# Altium schematic sheet LCD.SchDoc(LCD)
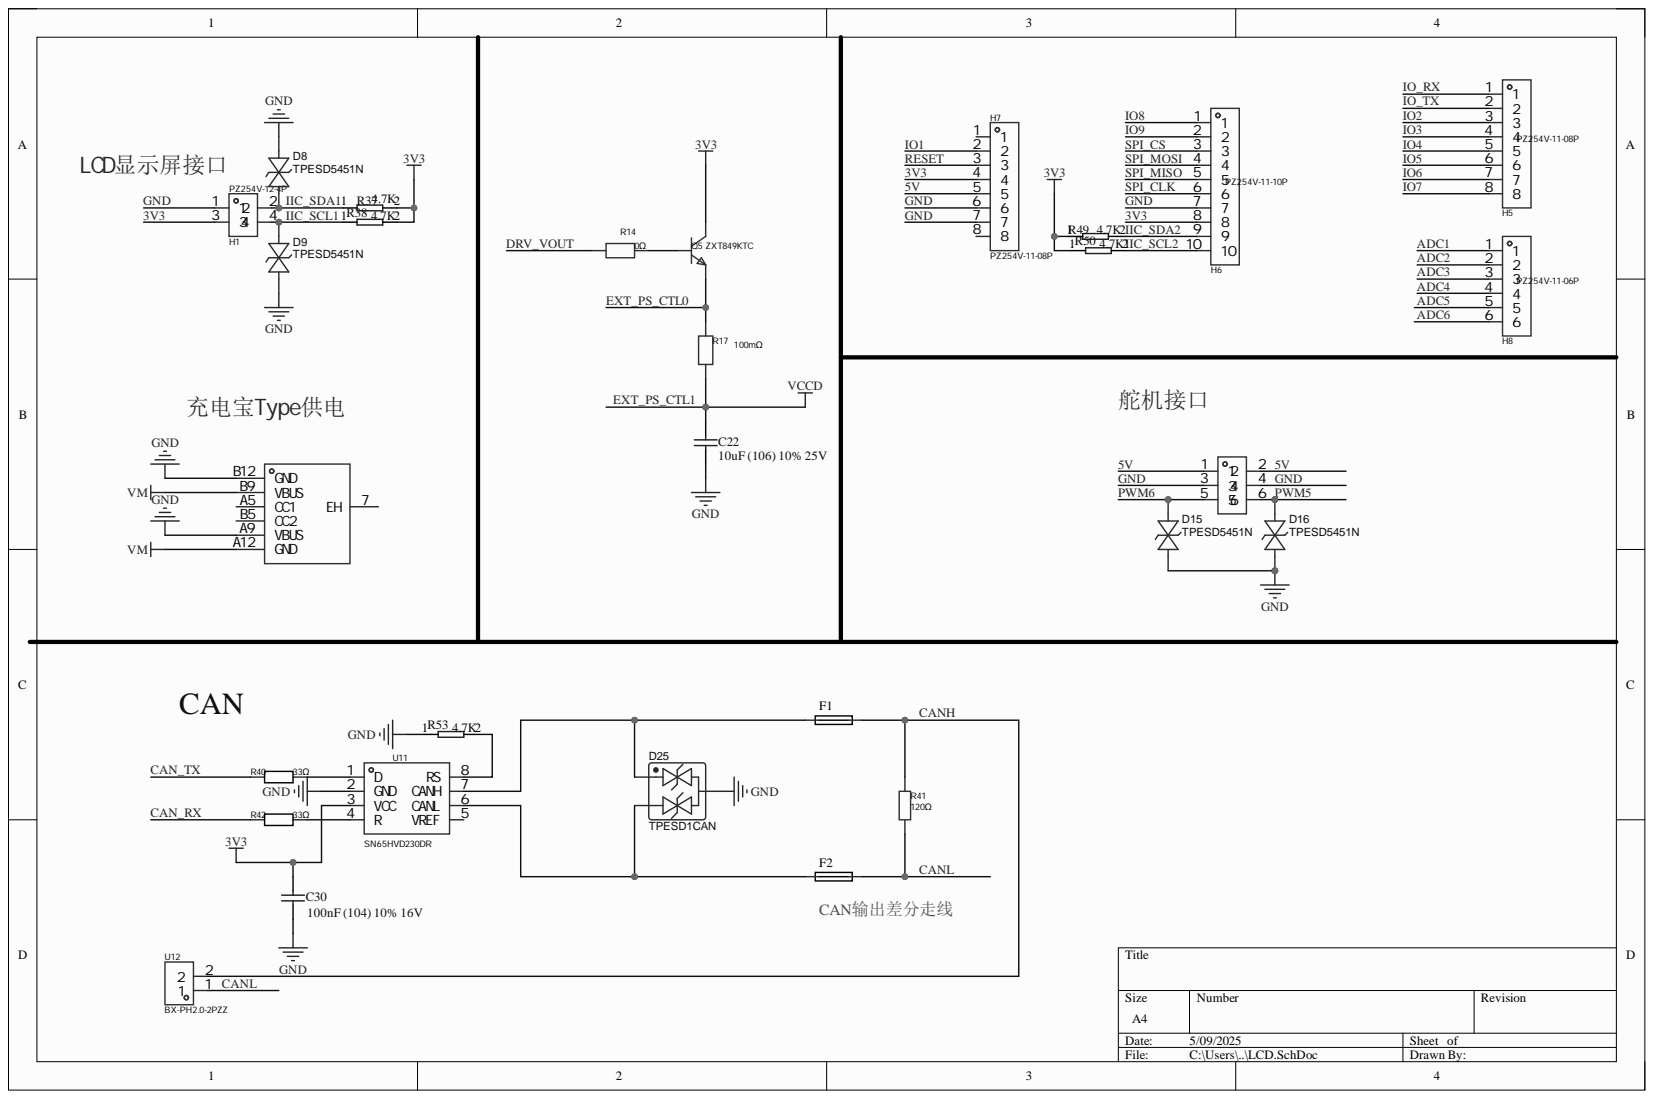
[diagram p0: LCD.SchDoc]

## Parts
C22  10uF (106) 10% 25V  [0805_C]
C30  100nF (104) 10% 16V  [0402_C]
D8  TPESD5451N  [DFN1006-2L-BI]
D9  TPESD5451N  [DFN1006-2L-BI]
D15  TPESD5451N  [DFN1006-2L-BI]
D16  TPESD5451N  [DFN1006-2L-BI]
D25  TPESD1CAN  [SOT-23-3_L2.9-W1.3-P1.90-LS2.4-BR]
F1  JK-SMD0805-005-15  [F0805]  (value not drawn)
F2  JK-SMD0805-005-15  [F0805]  (value not drawn)
H1  PZ254V-12-4P  [HDR-TH_4P-P2.54-V-M-R2-C2-S2.54]
H4  PZ254V-12-6P  [HDR-TH_6P-P2.54-V-M-R2-C3-S2.54]  (value not drawn)
H5  PZ254V-11-08P  [HDR-TH_8P-P2.54-V-M]
H6  PZ254V-11-10P  [HDR-TH_10P-P2.54-V-M]
H7  PZ254V-11-08P  [HDR-TH_8P-P2.54-V-M]
H8  PZ254V-11-06P  [HDR-TH_6P-P2.54-V-M]
Q5  ZXT849KTC  [TO-252-2_L6.6-W6.1-P4.57-LS9.9-BR-CW]
R14  0Ω  [0805_R]
R17  100mΩ  [R1206]
R37  4.7K  [R0603]
R38  4.7K  [R0603]
R40  33Ω  [0402_R]
R41  120Ω  [R0603]
R42  33Ω  [0402_R]
R49  4.7K  [R0603]
R50  4.7K  [R0603]
R53  4.7K  [R0603]
U11  SN65HVD230DR  [SOIC-8_L4.9-W3.9-P1.27-LS6.0-BL]
U12  BX-PH2.0-2PZZ  [CONN-TH_2P-P2.00_BOSSIE_BX-PH2.0-2PZZ]
USB2  TYPE-C 6P  [TYPE-C-SMD_TYPE-C-6P]  (value not drawn)

## Nets
VM: USB2.A12(GND) USB2.B9(VBUS)
3V3: C30.2 H1.3 H6.8 H7.4 Q5.2 R37.2 R38.2 R49.1 R50.1 U11.3(VCC)
5V: H4.1 H4.2 H7.5
ADC1: H8.1
ADC2: H8.2
ADC3: H8.3
ADC4: H8.4
ADC5: H8.5
ADC6: H8.6
CAN_RX: R42.1
CAN_TX: R40.1
CANH: F1.2 R41.2 U12.2
CANL: F2.2 R41.1 U12.1
DRV_VOUT: R14.1
EXT_PS_CTL0: Q5.3 R17.2
EXT_PS_CTL1: C22.2 R17.1
GND: C22.1 C30.1 D8.2 D9.1 D15.1 D16.1 D25.3 H1.1 H4.3 H4.4 H6.7 H7.6 H7.7 R53.1 U11.2(GND) USB2.A9(VBUS) USB2.B12(GND)
IIC_SCL1: D9.2 H1.4 R38.1
IIC_SCL2: H6.10 R50.2
IIC_SDA1: D8.1 H1.2 R37.1
IIC_SDA2: H6.9 R49.2
IO1: H7.2
IO2: H5.3
IO3: H5.4
IO4: H5.5
IO5: H5.6
IO6: H5.7
IO7: H5.8
IO8: H6.1
IO9: H6.2
IO_RX: H5.1
IO_TX: H5.2
PWM5: D16.2 H4.6
PWM6: D15.2 H4.5
RESET: H7.3
SPI_CLK: H6.6
SPI_CS: H6.3
SPI_MISO: H6.5
SPI_MOSI: H6.4
NetD25_1: D25.1 F1.1 U11.7(CANH)
NetD25_2: D25.2 F2.1 U11.6(CANL)
NetQ5_1: Q5.1 R14.2
NetR40_2: R40.2 U11.1(D)
NetR42_2: R42.2 U11.4(R)
NetR53_2: R53.2 U11.8(RS)

Not connected: H7 1 8; U11 5(VREF); USB2 7(EH) A5(CC1) B5(CC2)

## Library data of the parts
C22 = 0805 10uF (106) 10% 25V: 贴片电容; Component Kind: Standard; ComponentLink1Description: 供应商链接; ComponentLink1URL: http://www.szlcsc.com/product/details_16532.html; Library Name: Schlib1.SchLib; Package: 0805; Supplier: LC; SuppliersPartNumber: C15850
C30 = 0402 100nF (104) 10% 16V: 贴片电容; Component Kind: Standard; ComponentLink1Description: 供应商链接; ComponentLink1URL: http://www.szlcsc.com/product/details_1877.html; Library Name: Miscellaneous Devices LC.IntLib; Package: 0402; Supplier: LC; SuppliersPartNumber: C1525
D8 D9 D15 D16 = TPESD5451N: Manufacturer: TECH PUBLIC(台舟); Contributor: LCSC; Component Kind: Standard; JLCPCB Part Class: Extended Part; link: https://so.szlcsc.com/global.html?k=C970008&ref=editor; Manufacturer Part: TPESD5451N; spicePre: D; spiceSymbolName: TPESD5451N; Supplier: LCSC; Supplier Part: C970008
D25 = TPESD1CAN: Manufacturer: TECH PUBLIC(台舟); Contributor: LCSC; Component Kind: Standard; JLCPCB Part Class: Extended Part; Manufacturer Part: TPESD1CAN; spicePre: D; spiceSymbolName: TPESD1CAN; Supplier: LCSC; Supplier Part: C521949
F1 F2 = JK-SMD0805-005-15: Manufacturer: JK(金科); Add into BOM: yes; Current Rating (Max): 100A; Device: JK-SMD0805-075; Hold Current: 750mA; Maximum Time to Trip: 200ms; Operating Voltage (Max): 6V; Trip Current: 1.5A; Component Kind: Standard; Convert to PCB: yes; Datasheet: https://atta.szlcsc.com/upload/public/pdf/source/20190306/C369151_789C15D625FD73830E24950182550D65.pdf; JLCPCB Part Class: Extended Part; LCSC Part Name: 6V 750mA 0805自恢复; Manufacturer Part: JK-SMD0805-075; Supplier: LCSC; Supplier Footprint: 0805; Supplier Part: C369151; Symbol: JK-SMD0805-075; Library Name: TRANBOT_1.SCHLIB
H1 = PZ254V-12-4P: Manufacturer: XFCN(兴飞); Add into BOM: yes; Circluar Pins/Square Pins: 方针; Pin Structure: 2x2P; Pitch: 2.54mm; Color: 黑色; Component Kind: Standard; Contact Material: 黄铜; Contact Plating: -; Convert to PCB: yes; Current Rating (Max): -; Datasheet: https://atta.szlcsc.com/upload/public/pdf/source/20200309/C492419_0001C06E73AFF21BD0ED3D7CEEF46D29.pdf; Device: PZ254V-12-4P; Insulation Height: 2.5mm; JLCPCB Part Class: Extended Part; LCSC Part Name: 间距:2.54mm 2x2P 直插 方针; Length of End Connection Pin: 3mm; Length of Mating Pin: 6mm; Library Name: Schlib1.SchLib; Manufacturer Part: PZ254V-12-4P; Mounting Type: 直插; Number of Pins: 4P; Number of Rows: 双排; Operating Temperature Range: -40℃~+105℃; PCB3D: HDR-TH_4P-P2.54-M-LI-2X2P; Row Spacing: 2.54mm; Supplier: LCSC; Supplier Footprint: 插件,P=2.54mm; Supplier Part: C492419; Symbol: PZ254V-12-4P; Voltage Rating (Max): -
H4 = PZ254V-12-6P_1: Manufacturer: XFCN(兴飞); Add into BOM: yes; Circluar Pins/Square Pins: 方针; Pin Structure: 2x3P; Pitch: 2.54mm; Color: 黑色; Component Kind: Standard; Contact Material: 黄铜; Contact Plating: -; Convert to PCB: yes; Current Rating (Max): -; Datasheet: https://atta.szlcsc.com/upload/public/pdf/source/20200309/C492420_BAECB307B001054C09D200388220EAE6.pdf; Device: PZ254V-12-6P; Insulation Height: 2.5mm; JLCPCB Part Class: Extended Part; LCSC Part Name: 间距:2.54mm 2x3P 直插 方针; Length of End Connection Pin: 3mm; Length of Mating Pin: 6mm; Library Name: Schlib1.SchLib; Manufacturer Part: PZ254V-12-6P; Mounting Type: 直插; Number of Pins: 6P; Number of Rows: 双排; Operating Temperature Range: -40℃~+105℃; PCB3D: HDR-TH_6P-P2.54-V-M-R2-C3-S2.54; Row Spacing: 2.54mm; Supplier: LCSC; Supplier Footprint: 插件,P=2.54mm; Supplier Part: C492420; Symbol: PZ254V-12-6P; Voltage Rating (Max): -
H5 H7 = PZ254V-11-08P: Manufacturer: XFCN(兴飞); Add into BOM: yes; Circluar Pins/Square Pins: 方针; Pin Structure: 1x8P; Pitch: 2.54mm; Color: 黑色; Component Kind: Standard; Contact Material: 黄铜; Contact Plating: -; Convert to PCB: yes; Current Rating (Max): -; Datasheet: https://atta.szlcsc.com/upload/public/pdf/source/20200309/C492407_83A6FB26A2233368EDB9516968EC6149.pdf; Device: PZ254V-11-08P; Insulation Height: 2.5mm; JLCPCB Part Class: Extended Part; LCSC Part Name: 间距:2.54mm 1x8P 直插 方针; Length of End Connection Pin: 3mm; Length of Mating Pin: 6mm; Library Name: Schlib1.SchLib; Manufacturer Part: PZ254V-11-08P; Mounting Type: 直插; Number of Pins: 8P; Number of Rows: 单排; Operating Temperature Range: -40℃~+105℃; PCB3D: HDR-TH_8P-P2.54-V-M; Row Spacing: -; Supplier: LCSC; Supplier Footprint: 插件,P=2.54mm; Supplier Part: C492407; Symbol: PZ254V-11-08P; Voltage Rating (Max): -
H6 = PZ254V-11-10P: Manufacturer: XFCN(兴飞); Add into BOM: yes; Circluar Pins/Square Pins: 方针; Pin Structure: 1x10P; Pitch: 2.54mm; Color: 黑色; Component Kind: Standard; Contact Material: 黄铜; Contact Plating: -; Convert to PCB: yes; Current Rating (Max): -; Datasheet: https://atta.szlcsc.com/upload/public/pdf/source/20200309/C492409_129C9431BDD62EBBBD0A643B6AAB9FBD.pdf; Device: PZ254V-11-10P; Insulation Height: 2.5mm; JLCPCB Part Class: Extended Part; LCSC Part Name: 间距:2.54mm 1x10P 直插 方针; Length of End Connection Pin: 3mm; Length of Mating Pin: 6mm; Library Name: Schlib1.SchLib; Manufacturer Part: PZ254V-11-10P; Mounting Type: 直插; Number of Pins: 10P; Number of Rows: 单排; Operating Temperature Range: -40℃~+105℃; PCB3D: HDR2.54-M-LI-1X10P; Row Spacing: -; Supplier: LCSC; Supplier Footprint: 插件,P=2.54mm; Supplier Part: C492409; Symbol: PZ254V-11-10P; Voltage Rating (Max): -
H8 = PZ254V-11-06P: Manufacturer: XFCN(兴飞); Add into BOM: yes; Circluar Pins/Square Pins: 方针; Pin Structure: 1x6P; Pitch: 2.54mm; Color: 黑色; Component Kind: Standard; Contact Material: 黄铜; Contact Plating: -; Convert to PCB: yes; Current Rating (Max): -; Datasheet: https://atta.szlcsc.com/upload/public/pdf/source/20200309/C492405_597F1930F5B55CC3C7FD95458F1C3977.pdf; Device: PZ254V-11-06P; Insulation Height: 2.5mm; JLCPCB Part Class: Extended Part; LCSC Part Name: 间距:2.54mm 1x6P 直插 方针; Length of End Connection Pin: 3mm; Length of Mating Pin: 6mm; Library Name: Schlib1.SchLib; Manufacturer Part: PZ254V-11-06P; Mounting Type: 直插; Number of Pins: 6P; Number of Rows: 单排; Operating Temperature Range: -40℃~+105℃; PCB3D: HDR-TH_6P-P2.54-M-LI-1X6P; Row Spacing: -; Supplier: LCSC; Supplier Footprint: 插件,P=2.54mm; Supplier Part: C492405; Symbol: PZ254V-11-06P; Voltage Rating (Max): -
Q5 = ZXT849KTC: Manufacturer: DIODES(美台); Add into BOM: yes; Collector Current (Ic): 7A; Collector Cut-Off Current (Icbo): 20nA; Collector-Emitter Breakdown Voltage (Vceo): 30V; Collector-Emitter Saturation Voltage (VCE(sat)@Ic,Ib): 280mV@350mA,7A; DC Current Gain (hFE@Ic,Vce): 100@1A,1V; Power Dissipation (Pd): 4.2W; Transistor Type: NPN; Transition Frequency (fT): 100MHz; Component Kind: Standard; Convert to PCB: yes; Datasheet: https://atta.szlcsc.com/upload/public/pdf/source/20230810/AD6FA63F706DE072E852BFA8983B224C.pdf; Device: ZXT849KTC; JLCPCB Part Class: Extended Part; LCSC Part Name: 耐压:30V 电流:7A NPN; Manufacturer Part: ZXT849KTC; Operating Temperature: -55℃~+150℃@(Tj); PCB3D: TO-252-2L-3P_L6.6-W6.1-H2.3-LS10.1-P4.6; Supplier: LCSC; Supplier Footprint: TO-252(DPAK); Supplier Part: C5245622; Symbol: ZXT849KTC
R14 = FRC0805P000 TS: Manufacturer: FOJAN(富捷); Add into BOM: yes; Overload Voltage (Max): 150V; Power(Watts): 125mW; Tolerance: ±5%; Component Kind: Standard; Convert to PCB: yes; Datasheet: https://atta.szlcsc.com/upload/public/pdf/source/20211221/925EC7D7225764EE0046F22065062C87.pdf; Device: FRC0805P000 TS; JLCPCB Part Class: Extended Part; LCSC Part Name: 0Ω ±5% 125mW 厚膜电阻; Manufacturer Part: FRC0805P000 TS; Operating Temperature Range: -55℃~+155℃; PCB3D: R0805_L2.0-W1.3-H0.6; Supplier: LCSC; Supplier Footprint: 0805; Supplier Part: C2907288; Symbol: FRC0805P000 TS; Type: 厚膜电阻; Value: 0Ω
R17 = FRL1206JR100TS: Manufacturer: FOJAN(富捷); Add into BOM: yes; Overload Voltage (Max): 500V; Power(Watts): 250mW; Temperature Coefficient: ±600ppm/℃; Tolerance: ±5%; Component Kind: Standard; Convert to PCB: yes; Datasheet: https://atta.szlcsc.com/upload/public/pdf/source/20211221/925EC7D7225764EE0046F22065062C87.pdf; Device: FRL1206JR100TS; JLCPCB Part Class: Extended Part; LCSC Part Name: 100mΩ ±5% 250mW 厚膜电阻; Manufacturer Part: FRL1206JR100TS; Operating Temperature Range: -55℃~+155℃; PCB3D: R1206_L3.2-W1.6-H0.6; Supplier: LCSC; Supplier Footprint: 1206; Supplier Part: C2907394; Symbol: FRL1206JR100TS; Type: 厚膜电阻; Value: 100mΩ
R37 R38 R49 R50 R53 = Res: Component Kind: Standard; Library Name: CZ 3D.IntLib
R40 R42 = 0402WGF330JTCE: 33欧电阻; Manufacturer: UNI-ROYAL(厚声); Add into BOM: yes; Overload Voltage (Max): 50V; Power(Watts): 62.5mW; Temperature Coefficient: ±100ppm/℃; Tolerance: ±1%; Component Kind: Standard; Convert to PCB: yes; Datasheet: https://atta.szlcsc.com/upload/public/pdf/source/20200306/C422600_1E6D84923E4A46A82E41ADD87F860B5C.pdf; Device: 0402WGF330JTCE; JLCPCB Part Class: Basic Part; LCSC Part Name: 33Ω ±1% 62.5mW 厚膜电阻; Library Name: Schlib2.SchLib; Manufacturer Part: 0402WGF330JTCE; Operating Temperature Range: -55℃~+155℃; PCB3D: R0402_L1.0-W0.5-H0.5; Supplier: LCSC; Supplier Footprint: 0402; Supplier Part: C25105; Symbol: 0402WGF330JTCE; Type: 厚膜电阻; Value: 33Ω
R41 = 0603WAF1200T5E: Manufacturer: UNI-ROYAL(厚声); Add into BOM: yes; Overload Voltage (Max): 75V; Power(Watts): 100mW; Temperature Coefficient: ±100ppm/℃; Tolerance: ±1%; Component Kind: Standard; Convert to PCB: yes; Datasheet: https://atta.szlcsc.com/upload/public/pdf/source/20200306/C422600_1E6D84923E4A46A82E41ADD87F860B5C.pdf; Device: 0603WAF1200T5E; JLCPCB Part Class: Basic Part; LCSC Part Name: 120Ω ±1% 100mW 厚膜电阻; Manufacturer Part: 0603WAF1200T5E; Operating Temperature Range: -55℃~+155℃; PCB3D: R0603; Supplier: LCSC; Supplier Footprint: 0603; Supplier Part: C22787; Symbol: 0603WAF1200T5E; Type: 厚膜电阻; Value: 120Ω
U11 = SN65HVD230DR: CAN  收发芯片; Manufacturer: TI(德州仪器); Add into BOM: yes; Data Rate: 1Mbps; Component Kind: Standard; Convert to PCB: yes; Datasheet: https://www.ti.com/cn/lit/gpn/sn65hvd230; Device: SN65HVD230DR; JLCPCB Part Class: Extended Part; LCSC Part Name: 3.3-V CAN 收发器; Library Name: Schlib1.SchLib; Manufacturer Part: SN65HVD230DR; Operating Temperature: -40℃~+85℃; PCB3D: SOIC-8_L4.9-W3.9-H1.7-LS6.0-P1.27; Supplier: LCSC; Supplier Footprint: SOIC-8; Supplier Part: C12084; Supply Current: -; Supply Voltage: -; Symbol: SN65HVD230DR; Type: CAN收发器
U12 = BX-PH2.0-2PZZ: Manufacturer: Bossie(博锡); Add into BOM: yes; Current Rating (Max): 2A; Number of PINs Per Row: 2; Pins Structure: 1x2P; Pitch: 2mm; Reference Series: PH; Component Kind: Standard; Contact Material: 黄铜; Contact Plating: 锡; Convert to PCB: yes; Datasheet: https://atta.szlcsc.com/upload/public/pdf/source/20231017/0F5D8A357D6F7BFB4F7225D5BDBA6EB1.pdf; Device: BX-PH2.0-2PZZ; JLCPCB Part Class: Extended Part; LCSC Part Name: BX-PH2.0-2PZZ; Manufacturer Part: BX-PH2.0-2PZZ; Mounting Style: 直插; Number of Pins: 2P; Number of Rows: 1; Operating Temperature Range: -25℃~+85℃; Row Spacing: -; Supplier: LCSC; Supplier Footprint: 插件,P=2mm; Supplier Part: C18077744; Symbol: BX-PH2.0-2PZZ
USB2 = TYPE-C 6P: Manufacturer: SHOU HAN(首韩); Add into BOM: yes; Connect-Disconnect Life: 3千次; Core Colour: 黑色; Current Rating - Power (Max): 5A; Gender: 母; Number of Ports: 1; Rated Voltage (Max): 20V; Component Kind: Standard; Connector Type: Type-C; Convert to PCB: yes; Datasheet: https://atta.szlcsc.com/upload/public/pdf/source/20201203/C456012_B13F72044DF846EDBE9BDD0D1D3CD166.pdf; Device: TYPE-C 6P; JLCPCB Part Class: Extended Part; LCSC Part Name: Type-C 母 卧贴; Library Name: Schlib1.SchLib; Manufacturer Part: TYPE-C 6P; Mounting Style: 卧贴; Number of Contacts: 6P; Operating Temperature Range: -40℃~+85℃; Standard: USB 3.1; Supplier: LCSC; Supplier Footprint: SMD; Supplier Part: C456012; Symbol: TYPE-C 6P
